# Altium schematic sheet MCU_Core.SchDoc(MCU_Core)
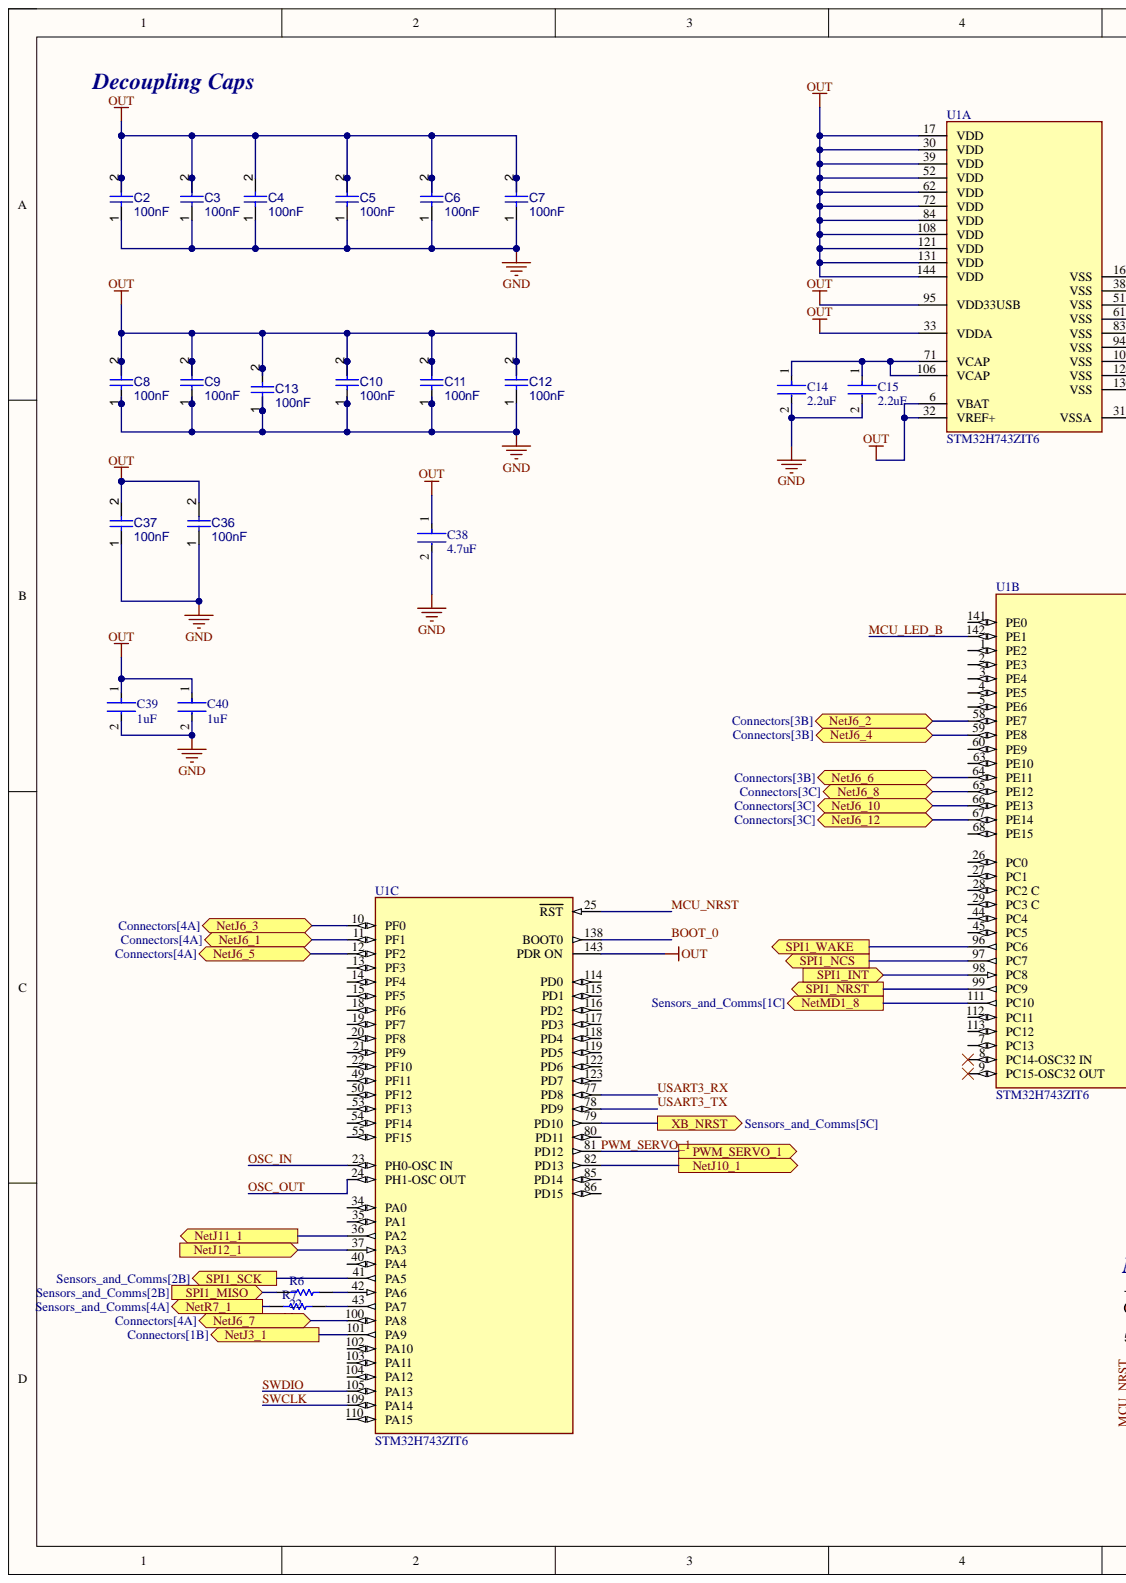
[diagram p0: MCU_Core.SchDoc, left]
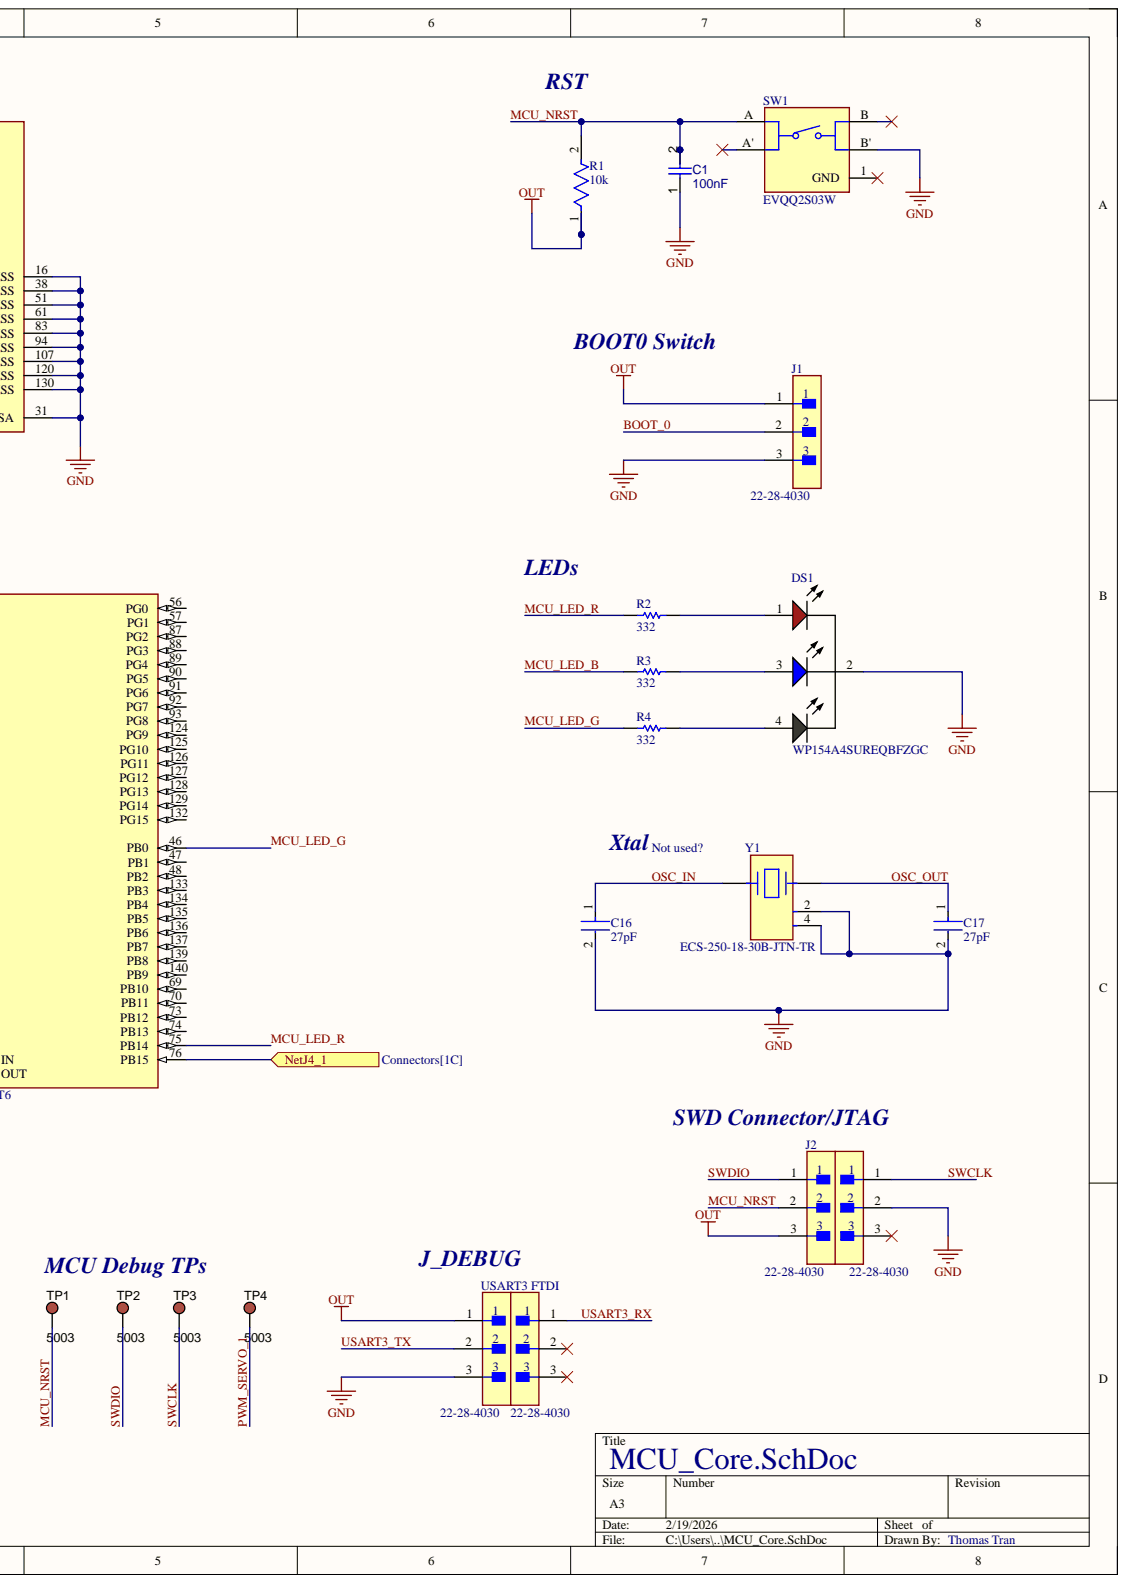
[diagram p1: MCU_Core.SchDoc, right]

## Parts
C1  100nF  [CAPC1608X90X40NL10T20]
C2  100nF  [CAPC1608X90X40NL10T20]
C3  100nF  [CAPC1608X90X40NL10T20]
C4  100nF  [CAPC1608X90X40NL10T20]
C5  100nF  [CAPC1608X90X40NL10T20]
C6  100nF  [CAPC1608X90X40NL10T20]
C7  100nF  [CAPC1608X90X40NL10T20]
C8  100nF  [CAPC1608X90X40NL10T20]
C9  100nF  [CAPC1608X90X40NL10T20]
C10  100nF  [CAPC1608X90X40NL10T20]
C11  100nF  [CAPC1608X90X40NL10T20]
C12  100nF  [CAPC1608X90X40NL10T20]
C13  100nF  [CAPC1608X90X40NL10T20]
C14  2.2uF  [FP-C1005-050-0_15-0_1-MFG]
C15  2.2uF  [FP-C1005-050-0_15-0_1-MFG]
C16  27pF  [FP-C0603C-CF-MFG]
C17  27pF  [FP-C0603C-CF-MFG]
C36  100nF  [CAPC1608X90X40NL10T20]
C37  100nF  [CAPC1608X90X40NL10T20]
C38  4.7uF  [FP-CC0805-0_85-MFG]
C39  1uF  [FP-C0402C105K8PACTU-MFG]
C40  1uF  [FP-C0402C105K8PACTU-MFG]
DS1  WP154A4SUREQBFZGC  [KING-WP154A4SUREQBFZGC_V]
J1  22-28-4030  [FP-22-28-4030-MFG]
J2  22-28-4030  [FP-22-28-4030-MFG]
R1  10k  [FP-RC0603-0_55-IPC_C]
R2  332  [RESC1608X55X25LL10T15]
R3  332  [RESC1608X55X25LL10T15]
R4  332  [RESC1608X55X25LL10T15]
R6  22  [RESC1005X40X25NL05T10]
R7  22  [RESC1005X40X25NL05T10]
SW1  EVQQ2S03W  [FP-EVQQ2S03W-0]
TP1  5003  [KSTN-5003]
TP2  5003  [KSTN-5003]
TP3  5003  [KSTN-5003]
TP4  5003  [KSTN-5003]
U1  STM32H743ZIT6  [FP-LQFP144-1A-MFG]  (parts A,B,C)
USART3_FTDI  22-28-4030  [FP-22-28-4030-MFG]
Y1  ECS-250-18-30B-JTN-TR  [FP-ECX-53B-MFG]
  22-28-4030  [FP-22-28-4030-MFG]
  22-28-4030  [FP-22-28-4030-MFG]

## Ports
GPS_NSRT, OB_GPIO1, OB_GPIO2, OB_I2C2_SCL, OB_I2C2_SDA, OB_SPI4_CS, OB_SPI4_MISO, OB_SPI4_MOSI, OB_SPI4_SCK, OB_UART7_RX, OB_UART7_TX, PWM_SERVO_1, PWM_SERVO_2, SPI1_INT, SPI1_MISO, SPI1_MOSI, SPI1_NCS, SPI1_NRST, SPI1_SCK, SPI1_WAKE, STM_GPS_RX, STM_GPS_TX, STM_XBEE_RX, STM_XBEE_TX, XB_NRST

## Nets
3V3: C2.2 C3.2 C4.2 C5.2 C6.2 C7.2 C8.2 C9.2 C10.2 C11.2 C12.2 C13.2 C36.2 C37.2 C38.1 C39.1 C40.1 J1.1 J2.3 R1.1 U1.6(VBAT) U1.17(VDD) U1.30(VDD) U1.32(VREF+) U1.33(VDDA) U1.39(VDD) U1.52(VDD) U1.62(VDD) U1.72(VDD) U1.84(VDD) U1.95(VDD33USB) U1.108(VDD) U1.121(VDD) U1.131(VDD) U1.143(PDR_ON) U1.144(VDD) USART3_FTDI.1
GND: C1.1 C2.1 C3.1 C4.1 C5.1 C6.1 C7.1 C8.1 C9.1 C10.1 C11.1 C12.1 C13.1 C14.2 C15.2 C16.2 C17.2 C36.1 C37.1 C38.2 C39.2 C40.2 DS1.2 J1.3 SW1.B' U1.16(VSS) U1.31(VSSA) U1.38(VSS) U1.51(VSS) U1.61(VSS) U1.83(VSS) U1.94(VSS) U1.107(VSS) U1.120(VSS) U1.130(VSS) USART3_FTDI.3 Y1.2(Gnd) Y1.4(Gnd) .2
Net_2: .2
Net_3: .3
BOOT_0: J1.2 U1.138(BOOT0)
GPS_NSRT: U1.111(PC10) ; port GPS_NSRT
MCU_LED_B: R3.1 U1.142(PE1)
MCU_LED_G: R4.1 U1.46(PB0)
MCU_LED_R: R2.1 U1.75(PB14)
MCU_NRST: C1.2 J2.2 R1.2 SW1.A TP1.1 U1.25(RST)
OB_GPIO1: U1.12(PF2) ; port OB_GPIO1
OB_GPIO2: U1.100(PA8) ; port OB_GPIO2
OB_I2C2_SCL: U1.11(PF1) ; port OB_I2C2_SCL
OB_I2C2_SDA: U1.10(PF0) ; port OB_I2C2_SDA
OB_SPI4_CS: U1.64(PE11) ; port OB_SPI4_CS
OB_SPI4_MISO: U1.66(PE13) ; port OB_SPI4_MISO
OB_SPI4_MOSI: U1.67(PE14) ; port OB_SPI4_MOSI
OB_SPI4_SCK: U1.65(PE12) ; port OB_SPI4_SCK
OB_UART7_RX: U1.58(PE7) ; port OB_UART7_RX
OB_UART7_TX: U1.59(PE8) ; port OB_UART7_TX
OSC_IN: C16.1 U1.23(PH0-OSC_IN) Y1.1
OSC_OUT: C17.1 U1.24(PH1-OSC_OUT) Y1.3
PWM_SERVO_1: TP4.1 U1.81(PD12) ; port PWM_SERVO_1
PWM_SERVO_2: U1.82(PD13) ; port PWM_SERVO_2
SPI1_INT: U1.98(PC8) ; port SPI1_INT
SPI1_MISO: R6.1 ; port SPI1_MISO
SPI1_NCS: U1.97(PC7) ; port SPI1_NCS
SPI1_NRST: U1.99(PC9) ; port SPI1_NRST
SPI1_SCK: U1.41(PA5) ; port SPI1_SCK
SPI1_WAKE: U1.96(PC6) ; port SPI1_WAKE
STM_GPS_RX: U1.76(PB15) ; port STM_GPS_RX
STM_GPS_TX: U1.101(PA9) ; port STM_GPS_TX
STM_XBEE_RX: U1.37(PA3) ; port STM_XBEE_RX
STM_XBEE_TX: U1.36(PA2) ; port STM_XBEE_TX
SWCLK: TP3.1 U1.109(PA14) .1
SWDIO: J2.1 TP2.1 U1.105(PA13)
USART3_RX: U1.77(PD8) .1
USART3_TX: U1.78(PD9) USART3_FTDI.2
XB_NRST: U1.79(PD10) ; port XB_NRST
NetC14_1: C14.1 C15.1 U1.71(VCAP) U1.106(VCAP)
NetDS1_1: DS1.1 R2.2
NetDS1_3: DS1.3 R3.2
NetDS1_4: DS1.4 R4.2
NetR6_2: R6.2 U1.42(PA6)
NetR7_1: R7.1 ; port SPI1_MOSI
NetR7_2: R7.2 U1.43(PA7)

Not connected: SW1 1(GND) A' B; U1 1(PE2) 2(PE3) 3(PE4) 4(PE5) 5(PE6) 7(PC13) 8(PC14-OSC32_IN) 9(PC15-OSC32_OUT) 13(PF3) 14(PF4) 15(PF5) 18(PF6) 19(PF7) 20(PF8) 21(PF9) 22(PF10) 26(PC0) 27(PC1) 28(PC2_C) 29(PC3_C) 34(PA0) 35(PA1) 40(PA4) 44(PC4) 45(PC5) 47(PB1) 48(PB2) 49(PF11) 50(PF12) 53(PF13) 54(PF14) 55(PF15) 56(PG0) 57(PG1) 60(PE9) 63(PE10) 68(PE15) 69(PB10) 70(PB11) 73(PB12) 74(PB13) 80(PD11) 85(PD14) 86(PD15) 87(PG2) 88(PG3) 89(PG4) 90(PG5) 91(PG6) 92(PG7) 93(PG8) 102(PA10) 103(PA11) 104(PA12) 110(PA15) 112(PC11) 113(PC12) 114(PD0) 115(PD1) 116(PD2) 117(PD3) 118(PD4) 119(PD5) 122(PD6) 123(PD7) 124(PG9) 125(PG10) 126(PG11) 127(PG12) 128(PG13) 129(PG14) 132(PG15) 133(PB3) 134(PB4) 135(PB5) 136(PB6) 137(PB7) 139(PB8) 140(PB9) 141(PE0)

## Library data of the parts
C1-C13 C36 C37 = CMP-001-00017-6: Chip Capacitor, 100 nF, +/- 10%, 50 V, 0603 (1608 Metric); Capacitor Type: Ceramic; Voltage Rating: 50V; Case/Package: 0603; Component Kind: Standard; Max Operating Temperature: 125°C; Min Operating Temperature: -55°C; Mounting Technology: SM; Pins: 2; RoHS Compliant: True; Tolerance: 10%; Value: 100nF; Library Name: Thomas Tran
C14 C15 = CMP-08246-004344-1: CAP CER 2.2UF 10V X7S 0402; Dielectric Material: Ceramic; Tolerance: 10%; Capacitance: 2.2uF; Case Code (Imperial): 0402; Case Code (Metric): 1005; Case/Package: 0402; Component Kind: Standard; Depth: 0.5mm; Dielectric: X7S; Height: 0.5mm; Lead Free: Lead Free; Length: 1mm; Library Name: Altium Content Vault; Max Operating Temperature: 125°C; Min Operating Temperature: -55°C; Mount: Surface Mount; Package Quantity: 1; Packaging: Tape and Reel; Resistor Type: Ceramic; RoHS Compliant: Yes; Series: C; Termination: SMD/SMT; Thickness: 0.022inch; Voltage Rating: 10V; Voltage Rating (DC): 10V; Weight: 2.3E-05oz; Width: 0.02inch
C16 C17 = CMP-03020-019774-1: C0603 27 pF C0G 30ppm/°C 10.00% 50 V; Manufacturer: KEMET; Manufacturer Part Number: C0603C270K5GAC; Automotive Grade: Grade 1; Material: Ceramic; Tolerance: 0.1; Voltage Rating: 50 V; Automotive: Yes; Capacitance: 27 pF; Category: Cap; Component Kind: Standard; ComponentLink2Description: Datasheet; ComponentLink2URL: https://api.kemet.com/component-edge/download/datasheet/C0603C100J5GACAUTO.pdf; Device Class L1: Passive Components; Device Class L2: Capacitors; Device Class L3: Ceramic Capacitors; Height: 0.87mm; Lead Free: Yes; Library Name: Altium Content Vault; Mouser Description: Multilayer Ceramic Capacitors MLCC - SMD/SMT 50volts 27pF 10% C0G; Octopart: https://octopart.com/c0603c270k5gac-kemet-8089732; Package: C0603C-CF; RoHS: TRUE; Temperature: -55°C to +125°C; Temperature Characteristic: C0G; Temperature Coefficient: 30ppm/°C; Temperature Range High: +125°C; Temperature Range Low: -55°C; Value: 27 pF
C38 = CMP-03422-000306-1: CAP CER 4.7UF 25V X7R 0805; Manufacturer: Yageo; Manufacturer Part Number: CC0805KKX7R8BB475; Material: Ceramic; Tolerance: 0.1; Automotive: No; Capacitance: 4.7 uF; Category: Cap; Component Kind: Standard; ComponentLink2Description: Datasheet; ComponentLink2URL: http://www.yageo.com/documents/recent/UPY-GPHC_Y5V_6.3V-to-50V_8.pdf; Device Class L1: Passive Components; Device Class L2: Capacitors; Device Class L3: Ceramic Capacitors; Digikey Description: CAP CER 4.7UF 25V X7R 0805; Footprint Url: http://www.yageo.com/exep/pages/download/literatures/UPY-C_GEN_24.pdf; Height: 0.95mm; Ipc Land Pattern Name: CAPC200125X85; Lead Free: Yes; Library Name: Altium Content Vault; Mouser Description: Multilayer Ceramic Capacitors MLCC - SMD/SMT 4.7M pF 10% 25 Volts; Octopart: https://octopart.com/cc0805kkx7r8bb475-yageo-51849247; Package: CC0805-0.85; RoHS: TRUE; Temperature: -30°C to +85°C; Temperature Characteristic: X7R; Temperature Range High: +85°C; Temperature Range Low: -30°C; Value: 4.7 uF; Voltage Rating: 25 V
C39 C40 = CMP-2008-02081-2: CAP CER 1UF 10V X5R 0402; Dielectric Material: Ceramic; Dissipation Factor: 5%; Tolerance: 10%; Capacitance: 1uF; Case Code (Imperial): 0402; Case Code (Metric): 1005; Case/Package: 0402; Component Kind: Standard; Construction: Flat; Contact Plating: Tin; Depth: 0.5mm; Dielectric: X5R; Height: 0.5mm; Lead Free: Lead Free; Length: 1mm; Library Name: Altium Content Vault; Max Operating Temperature: 85°C; Min Operating Temperature: -55°C; Mount: Surface Mount; Number of Pins: 2; Package Quantity: 1; Packaging: Tape and Reel; Radiation Hardening: No; REACH SVHC: No SVHC; RoHS Compliant: Yes; Series: C; Termination: SMD/SMT; Thickness: 0.022inch; Voltage: 10V; Voltage Rating: 10V; Voltage Rating (DC): 10V; Width: 0.5mm
DS1 = CMP-2000-07415-1: Full Color LED Lamp, Dominant Wavelength RGB 630 / 525 / 465 nm, -40 to 85 degC, 4-Pin THD, RoHS; Manufacturer: Kingbright; 3D Model: WP154A4SUREQBFZGW.sldprt    WP154A4SUREQBFZGW.pro    WP154A4SUREQBFZGW.igs    WP154A4SUREQBFZGW.stp; Color: Red,Green,Blue(RGB); Current - Test: 20mA; Lens Style/Size: RoundwithDomedTop,5mm,T-13/4; Lens Type: Clear; Millicandela Rating: 1300mcdRed,1700mcdGreen,900mcdBlue; Online Catalog: 5mm,T-13/4(RGB)RoundwithDomedTopLEDLamp; Other Names: 754-1615  WP154A4SUREQBFZGC-ND; Viewing Angle: 50°; Voltage - Forward (Vf) (Typ): 1.9VRed,3.3VGreen,3.3VBlue; Wavelength - Dominant: 630nm,525nm,465nm; Wavelength - Peak: 645nm,515nm,460nm; Category: Optoelectronics; Component Kind: Standard; Datasheet URL: https://www.kingbrightusa.com/images/catalog/spec/WP154A4SUREQBFZGC.pdf; Datasheet Version: Rev. 5A, 08/2014; Family: LEDIndication-Discrete; Height: 8.60mm; Library Name: Altium Content Vault; Manufacturer URL: http://www.kingbright.com/; Mounting Technology: ThroughHole; Mounting Type: ThroughHole; Package / Case: Radial-4Leads; Package Description: 4-Pin Through Hole LED, Dia 5.9 mm, Pitch 1.27 mm; Package Reference: WP154A4SUREQBFZGC; Packaging: Bulk; Standard Package: 500
J1 J2 USART3_FTDI   = CMP-04776-000098-1: CONN HEADER VERT 3POS 2.54MM; Manufacturer: Molex; Conn Gender: Male; Conn Orientation: Vertical; Current Rating: 4A; Number Of Contacts: 3; Voltage Rating: 500V; Automotive: No; Category: Conn; Component Kind: Standard; ComponentLink2Description: Datasheet; ComponentLink2URL: https://www.molex.com/webdocs/datasheets/pdf/en-us/0022284030_PCB_HEADERS.pdf; Contact Material: Gold,Nickel; Device Class L1: Connectors; Device Class L2: Headers and Wire Housings; Device Class L3: unset; Digikey Description: CONN HEADER VERT 3POS 2.54MM; Footprint Url: https://www.molex.com/pdm_docs/sd/022284030_sd.pdf; Height: 8.88mm; Lead Free: Yes; Library Name: Altium Content Vault; Manufacturer Part Number: 22-28-4030; Mouser Description: Headers & Wire Housings 3P VERT HEADER Sn; Number Of Rows: 1; Octopart: https://octopart.com/0022284030-molex-10476094; Package: 22-28-4030; Pitch: 2.54mm; RoHS: TRUE; Temperature: -40°C to +80°C; Temperature Range High: +80°C; Temperature Range Low: -40°C
R1 = CMP-2100-03664-2: RES 10K OHM 1% 1/10W 0603; Max Power Dissipation: 100mW; Resistance: 10kR; Temperature Coefficient: 100ppm/°C; Voltage Rating: 75V; Voltage Rating (DC): 50V; Case Code (Imperial): 0603; Case Code (Metric): 1608; Case/Package: 0603; Component Kind: Standard; Construction: Rectangular; Depth: 0.8mm; Features: Moisture Resistant; Height: 0.45mm; Lead Free: Lead Free; Lead Pitch: 0.3mm; Length: 1.6mm; Library Name: Altium Content Vault; Max Operating Temperature: 155°C; Military Standard: Not; Min Operating Temperature: -55°C; Number of Pins: 2; Number of Terminations: 2; Packaging: Tape and Reel; Power Rating: 100mW; Resistance Tolerance: 1%; Resistor Type: Thick Film; RoHS Compliant: Yes; Series: RC; Size Code: 0603; Termination: SMD/SMT; Tolerance: 1%; Weight: 7.1E-05oz; Width: 0.8mm
R2-R4 = CMP-2000-04013-1: Manufacturer: Yageo; Part Number: RC0603FR-07332RL; Component Kind: Standard; Library Name: Altium Content Vault
R6 R7 = CMP-2002-07519-1: Manufacturer: Yageo; Part Number: RC0402FR-0722R6L; Component Kind: Standard; Library Name: Altium Content Vault
SW1 = CMP-05618-000009-1: SWITCH TACTILE SPST-NO 0.02A 15V; Manufacturer: Panasonic; Contact Current Rating: 20mA; Contact Resistance: 100mΩ; Electromechanical Life: 1000000Cycles; Operating Force: 1.6N; Standoff Height: 0mm; Automotive: No; Category: Switch; Component Kind: Standard; ComponentLink2Description: Datasheet; ComponentLink2URL: https://industrial.panasonic.com/ac/cdn/e/control/switch/light-touch/catalog/sw_lt_eng_6s_th.pdf; Device Class L1: Electromechanical; Device Class L2: Switches; Device Class L3: Tactile Switches; Digikey Description: SWITCH TACTILE SPST-NO 0.02A 15V; Height: 3.3mm; Lead Free: Yes; Library Name: Altium Content Vault; Manufacturer Part Number: EVQQ2S03W; Mount: Surface Mount; Mouser Description: Tactile Switches Switch Light Touch 6mm Square SMD; Octopart: https://octopart.com/evq-q2s03w-panasonic-1199659; Package: EVQQ2S03W; RoHS: TRUE; Temperature: -40°C to +85°C; Temperature Range High: +85°C; Temperature Range Low: -40°C; Throw Configuration: SPST-NO; Voltage Rating Dc: 15V
TP1-TP4 = CMP-002-00037-2: Test Point, Orange, Height 4.6 mm, Tail Length 3 mm, 1-Pin THD, RoHS; Manufacturer: Keystone; Case/Package: 5003; Colour: Orange; Component Kind: Standard; Connector Type: TestPoint; Contact Material: Bronze; Contact Plating: Tin; Datasheet URL: http://keyelco.com/userAssets/file/M65p56.pdf; Datasheet Version: Jun-15; Diameter: 2.54mm; Manufacturer URL: http://www.keyelco.com/; Mounting Technology: TH; Package Description: 1-Pin Through Hole Device, Dia 2.54 mm; Package Quantity: 10; Package Reference: 5003; Packaging: Bulk; Pins: 1; RoHS Compliant: True; Library Name: Thomas Tran
U1 = CMP-12105-000003-1: IC MCU 32BIT 2MB FLASH 144LQFP; Manufacturer: STMicroelectronics; Core Architecture: ARM Cortex-M7; Data Bus Width: 32bits; Frequency: 400MHz; Interface: I2C,SPI,UART,USART,EBI/EMI,CAN,USB,LIN,IrDA,Other; Max Junction Temp: +125°C; Max Supply Voltage: 3.6V; Memory Size: 2MBytes; Min Supply Voltage: 1.62V; Number Of A/D Converters: 2; Number Of Adc Channels: 36; Number Of Cores: 1; Number Of I2C Channels: 4; Number Of I/Os: 114; Number Of Spi Channels: 6; Number Of Timers/Counters: 22; Ram Size: 1MBytes; Standoff Height: 0.05mm; Ambient Temperature Range High: +85°C; Ambient Temperature Range Low: -40°C; Automotive: No; Category: IC; Component Kind: Standard; ComponentLink2Description: Datasheet; ComponentLink2URL: https://www.st.com/resource/en/datasheet/stm32h743zi.pdf; Device Class L1: Integrated Circuits (ICs); Device Class L2: Embedded Processors and Controllers; Device Class L3: Microcontrollers; Digikey Description: IC MCU 32BIT 2MB FLASH 144LQFP; Height: 1.6mm; Ipc Land Pattern Name: QFP50P2200X2200X160-144; Lead Free: Yes; Library Name: Altium Content Vault; Manufacturer Part Number: STM32H743ZIT6; Memory Type: FLASH; Mouser Description: MCU 32-Bit STM32 ARM Cortex M7 RISC 2MB Flash 1.8V/2.5V/3.3V 144-Pin LQFP Tray; Number Of Pwm Channels: 2; Number Of Uart Channels: 4; Number Of Usb Channels: 2; Octopart: https://octopart.com/stm32h743zit6-stmicroelectronics-76372529; Package: LQFP144-1A; RoHS: TRUE; Temperature: -40°C to +85°C; Temperature Range High: +85°C; Temperature Range Low: -40°C
Y1 = CMP-00466-88189916-1: ECS-250-18-30B-JTN-TR Crystal 25MHz ±20ppm (Tol) ±20ppm (Stability) 18pF FUND 30Ohm 4-Pin Mini-SMD T/R; Component Kind: Standard; Library Name: Altium Content Vault; NexarPartId: 88189916; RoHS: Non-Compliant; Schedule B: 8541600060, 8541600060
